# Altium schematic sheet 02_ESP32.SchDoc(02_ESP32)
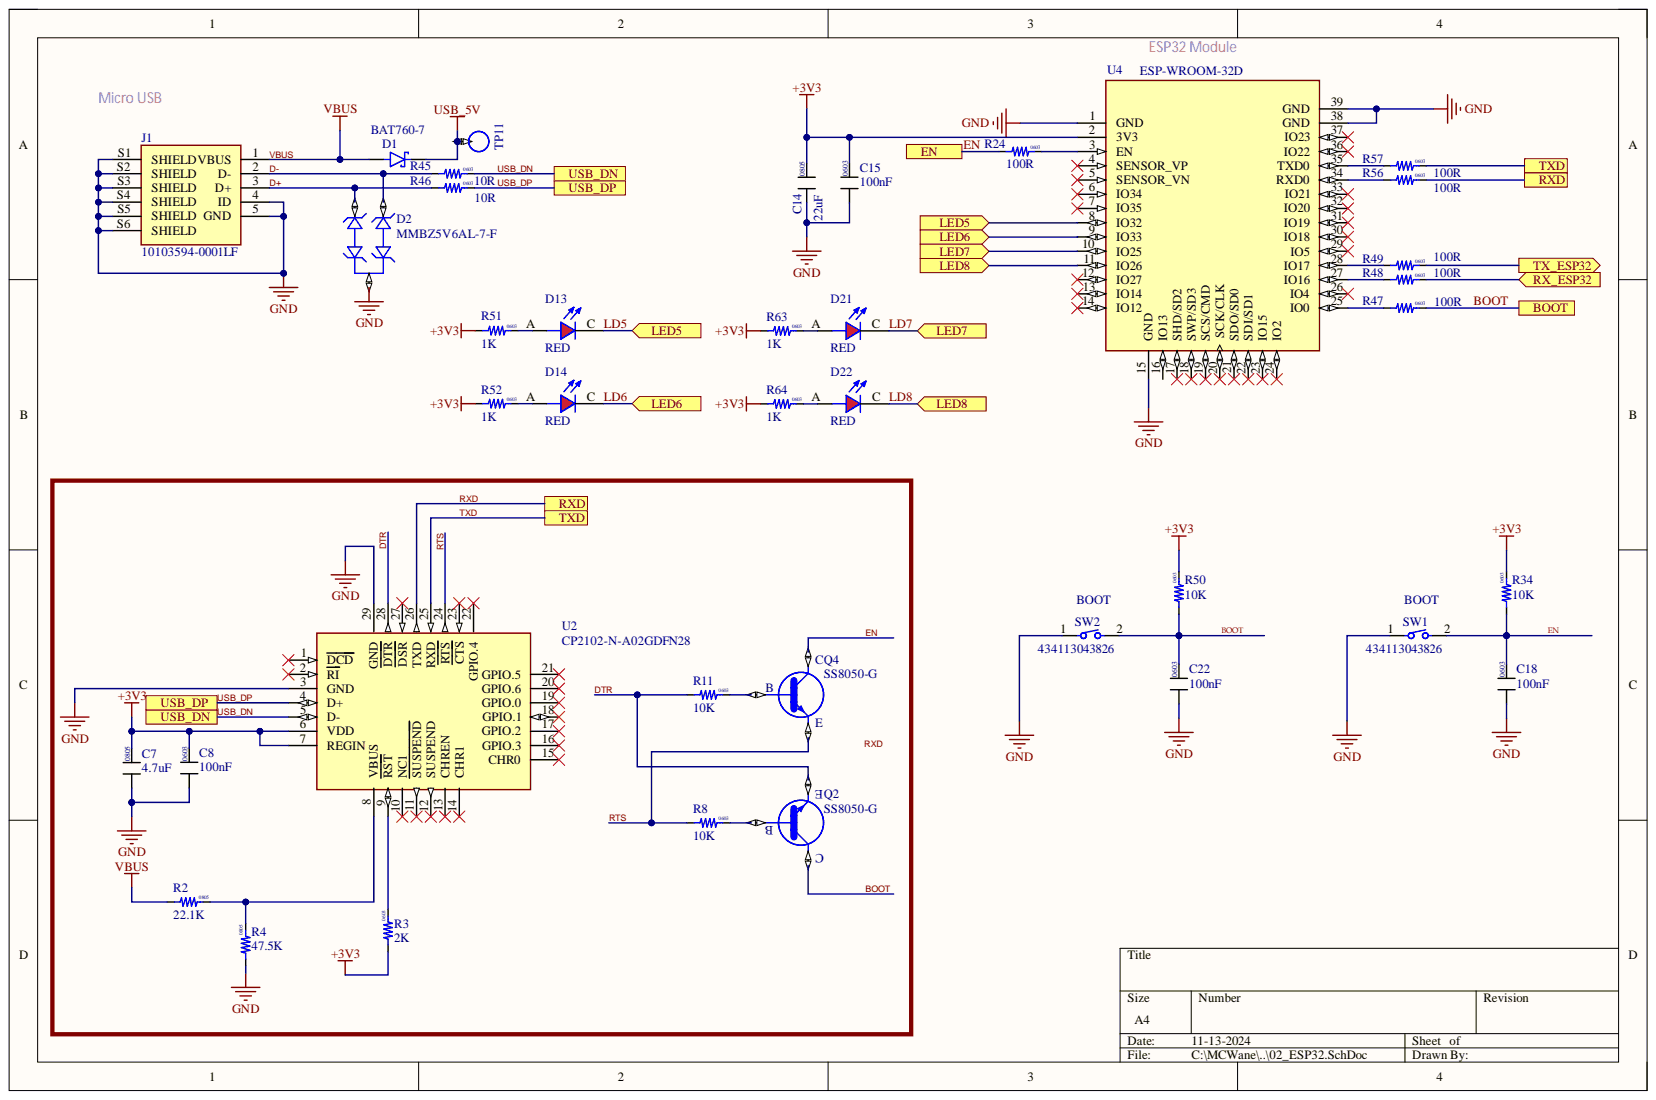
[diagram p0: 02_ESP32.SchDoc]

## Parts
C7  4.7uF  Package: 0805  [CAP_0805]
C8  100nF  Package: 0603  [CAP_0603]
C14  22uF  Package: 0805  [CAP_0805]
C15  100nF  Package: 0603  [CAP_0603]
C18  100nF  Package: 0603  [CAP_0603]
C22  100nF  Package: 0603  [CAP_0603]
D1  BAT760-7  [SOD323]
D2  MMBZ5V6AL-7-F  [SOT23]
D13  RED  [LED_0603]
D14  RED  [LED_0603]
D21  RED  [LED_0603]
D22  RED  [LED_0603]
J1  10103594-0001LF  [USB_MICRO_B_10118194-0001LF]
Q2  SS8050-G  [SOT23]
Q4  SS8050-G  [SOT23]
R2  22.1K  Package: 0805  [RES_0805]
R3  2K  Package: 0603  [RES_0603]
R4  47.5K  Package: 0805  [RES_0805]
R8  10K  Package: 0603  [RES_0603]
R11  10K  Package: 0603  [RES_0603]
R24  100R  Package: 0603  [RES_0603]
R34  10K  Package: 0603  [RES_0603]
R45  10R  Package: 0603  [RES_0603]
R46  10R  Package: 0603  [RES_0603]
R47  100R  Package: 0603  [RES_0603]
R48  100R  Package: 0603  [RES_0603]
R49  100R  Package: 0603  [RES_0603]
R50  10K  Package: 0603  [RES_0603]
R51  1K  Package: 0603  [RES_0603]
R52  1K  Package: 0603  [RES_0603]
R56  100R  Package: 0603  [RES_0603]
R57  100R  Package: 0603  [RES_0603]
R63  1K  Package: 0603  [RES_0603]
R64  1K  Package: 0603  [RES_0603]
SW1  434113043826  [Tactile_Switch_SPST_2Pin]
SW2  434113043826  [Tactile_Switch_SPST_2Pin]
TP11  TP  [TEST_POINT_SMD]
U2  CP2102-N-A02GDFN28  [QFN50P500X500X100-29N]
U4  ESP-WROOM-32D  [MODULE_ESP32-WROOM-32D]

## Ports
BOOT, EN, LED5, LED6, LED7, LED8, RX_ESP32, RXD, TX_ESP32, TXD, USB_DN, USB_DP

## Nets
+3V3: C7.2 C8.2 C14.2 C15.2 R3.1 R34.2 R50.2 R51.1 R52.1 R63.1 R64.1 U2.6(VDD) U2.7(REGIN) U4.2(3V3)
GND: C7.1 C8.1 C14.1 C15.1 C18.1 C22.1 D2.3 J1.4(ID) J1.5(GND) J1.S1(SHIELD) J1.S2(SHIELD) J1.S3(SHIELD) J1.S4(SHIELD) J1.S5(SHIELD) J1.S6(SHIELD) R4.1 SW1.1 SW2.1 U2.3(GND) U2.29(GND) U4.1(GND) U4.15(GND) U4.38(GND) U4.39(GND)
USB_5V: D1.C TP11.1
BOOT: C22.2 Q2.3 R47.2 R50.1 SW2.2 ; port BOOT
D+: D2.1 J1.3(D+) R46.1
D-: D2.2 J1.2(D-) R45.1
DTR: Q2.2 R11.1 U2.28(DTR)
EN: C18.2 Q4.3 R24.1 R34.1 SW1.2 ; port EN
LD5: D13.C U4.8(IO32) ; port LED5
LD6: D14.C U4.9(IO33) ; port LED6
LD7: D21.C U4.10(IO25) ; port LED7
LD8: D22.C U4.11(IO26) ; port LED8
RTS: Q4.2 R8.1 U2.24(RTS)
RX_ESP32: R48.2 ; port RX_ESP32
RXD: R56.2 U2.26(TXD) ; port RXD
TX_ESP32: R49.2 ; port TX_ESP32
TXD: R57.2 U2.25(RXD) ; port TXD
USB_DN: R45.2 U2.5(D-) ; port USB_DN
USB_DP: R46.2 U2.4(D+) ; port USB_DP
VBUS: D1.A J1.1(VBUS) R2.1
NetD13_A: D13.A R51.2
NetD14_A: D14.A R52.2
NetD21_A: D21.A R63.2
NetD22_A: D22.A R64.2
NetQ2_1: Q2.1 R8.2
NetQ4_1: Q4.1 R11.2
NetR2_2: R2.2 R4.2 U2.8(VBUS)
NetR3_2: R3.2 U2.9(RST)
NetR24_2: R24.2 U4.3(EN)
NetR47_1: R47.1 U4.25(IO0)
NetR48_1: R48.1 U4.27(IO16)
NetR49_1: R49.1 U4.28(IO17)
NetR56_1: R56.1 U4.34(RXD0)
NetR57_1: R57.1 U4.35(TXD0)

Not connected: U2 1(DCD) 2(RI) 10(NC1) 11(SUSPEND) 12(SUSPEND) 13(CHREN) 14(CHR1) 15(CHR0) 16(GPIO.3) 17(GPIO.2) 18(GPIO.1) 19(GPIO.0) 20(GPIO.6) 21(GPIO.5) 22(GPIO.4) 23(CTS) 27(DSR); U4 4(SENSOR_VP) 5(SENSOR_VN) 6(IO34) 7(IO35) 12(IO27) 13(IO14) 14(IO12) 16(IO13) 17(SHD/SD2) 18(SWP/SD3) 19(SCS/CMD) 20(SCK/CLK) 21(SDO/SD0) 22(SDI/SD1) 23(IO15) 24(IO2) 26(IO4) 29(IO5) 30(IO18) 31(IO19) 32(IO20) 33(IO21) 36(IO22) 37(IO23)

## Library data of the parts
C7 = C0805-4.7uF 25V-MLCC: SMD Multilayer Ceramic Capacitor, 4.7 µF, 25 V, 0805 [2012 Metric], ± 10%, X7R, -55 °C; Manufacturer Part Number: CC0805KKX7R8BB475; Brand: YAGEO; Marking: 475; Suppplier: ELEMENT 14; Component: Capacitor; Component Kind: Standard; Library Name: Passive_Components.SchLib
C8 C15 C18 C22 = C0603-0.1uF 50V-MLCC: SMD Multilayer Ceramic Capacitor, 0.1 µF, 50 V, 0603 [1608 Metric], ± 10%, X7R; Manufacturer Part Number: CC0603KRX7R9BB104; Brand: YAGEO; Marking: 104; Component: Capacitor; Component Kind: Standard; LCSC Part: C14663; Library Name: Passive_Components.SchLib; Supplier: ELEMENT 14
C14 = C0805-22uF 25V-MLCC: SMD Multilayer Ceramic Capacitor, 22 µF, 25 V, 0805 [2012 Metric], ± 20%, X5R, CC; Manufacturer Part Number: CC0805MKX5R8BB226; Brand: YAGEO; Marking: 226; Component: Capacitor; Component Kind: Standard; LCSC Part: C784585; Library Name: Passive_Components.SchLib; Supplier: ELEMENT 14
D1 = BAT760-7: Schottky Rectifier, 30 V, 1 A, Single, SOD-323, 2 Pins, 550 mV; Brand: DIODES INC.; Marking: LZ; Component Kind: Standard; Library Name: Active_Components.SchLib; Manufacturer Part Number: BAT760-7; Package: SOD-323
D2 = MMBZ5V6AL-7-F: ESD Suppressors / TVS Diodes 5.6V 225mW; Brand: Diodes Incorporated; Component Kind: Standard; Library Name: Active_Components.SchLib; Manufacturer Part Number: MMBZ5V6AL-7-F; Package: SOT-23-3
D13 D14 D21 D22 = RED_LED_0603: 5mA 28.5mcd 2.2V 632nm Colorless transparence -40℃~+85℃ 617.5nm~633.5nm Red 120° 60mW 0603(LED); Manufacturer Part Number: 19-217/R6C-AL1M2VY/3T; Brand: Everlight Elec; Component Kind: Standard; Library Name: Active_Components.SchLib; Package: 0603
J1 = 10103594-0001LF: USB Connector, Micro USB Type B, USB 2.0, Receptacle, 5 Ways, Surface Mount, Right Angle; Brand: Amphenol ICC (FCI); Component Kind: Standard; Library Name: CONNECTOR.SchLib; Manufacturer Part Number: 10103594-0001LF; Package: Surface Mount, Right Angle; Through Hole
Q2 Q4 = SS8050-G: Bipolar Transistors - BJT NPN TRANSISTOR 1.5A 40V; Brand: Comchip Technology; Component Kind: Standard; Library Name: Active_Components.SchLib; Manufacturer Part Number: SS8050-G; Package: SOT23-3
R2 = R0805-22.1K-1%: SMD Chip Resistor, 22.1 kohm, ± 1%, 125 mW, 0805 [2012 Metric], Thick Film, General Purpose; Manufacturer Part Number: RC0805FR-0722K1L; Brand: YAGEO; Marking: 2212; Component: Resistor; Component Kind: Standard; Library Name: Passive_Components.SchLib; Supplier: ELEMENT 14
R3 = R0603-2K-1%: SMD Chip Resistor, 2 kohm, ± 1%, 100 mW, 0603 [1608 Metric], Thick Film, General Purpose; Manufacturer Part Number: RC0603FR-072KL; Brand: YAGEO; Marking: 2001; Component: Resistor; Component Kind: Standard; LCSC Part: C105576; Library Name: Passive_Components.SchLib; Supplier: ELEMENT 14
R4 = R0805-47.5K-1%: SMD Chip Resistor, 47.5 kohm, ± 1%, 125 mW, 0805 [2012 Metric], Thick Film, General Purpose; Manufacturer Part Number: RC0805FR-0747K5L; Brand: YAGEO; Marking: 4752; Component: Resistor; Component Kind: Standard; Library Name: Passive_Components.SchLib; Supplier: ELEMENT 14
R8 R11 R34 R50 = R0603-10K-1%: SMD Chip Resistor, 10 kohm, ± 1%, 100 mW, 0603 [1608 Metric], Thick Film, General Purpose; Manufacturer Part Number: RC0603FR-0710KL; Brand: YAGEO; Marking: 1002; Component: Resistor; Component Kind: Standard; LCSC Part: C98220; Library Name: Passive_Components.SchLib; Supplier: ELEMENT 14
R24 R47-R49 R56 R57 = R0603-100E-1%: SMD Chip Resistor, 100 ohm, ± 1%, 100 mW, 0603 [1608 Metric], Thick Film, General Purpose; Manufacturer Part Number: RC0603FR-07100RL; Brand: YAGEO; Component: Resistor; Component Kind: Standard; Library Name: Passive_Components.SchLib; Marking: 100R; Supplier: ELEMENT 14
R45 R46 = R0603-10E-1%: SMD Chip Resistor, 10 ohm, ± 1%, 100 mW, 0603 [1608 Metric], Thick Film, General Purpose; Manufacturer Part Number: RC0603FR-0710RL; Brand: YAGEO; Marking: 10RO; Component: Resistor; Component Kind: Standard; LCSC Part: C109318; Library Name: Passive_Components.SchLib; Supplier: ELEMENT 14
R51 R52 R63 R64 = R0603-1K-1%: SMD Chip Resistor, 1 kohm, ± 1%, 100 mW, 0603 [1608 Metric], Thick Film, General Purpose; Manufacturer Part Number: RC0603FR-071KL; Brand: YAGEO; Marking: 1001; Component: Resistor; Component Kind: Standard; LCSC Part: C109318; Library Name: Passive_Components.SchLib; Supplier: ELEMENT 14
SW1 SW2 = 434113043826: Tactile Switches WS-TASV Tact Switch; Brand: Wurth Elektronik; Component Kind: Standard; Library Name: CONNECTOR.SchLib; Manufacturer Part Number: 434113043826; Package: 6mmx3.5mm, SMD
TP11 = TEST_POINT_SMD: Component Kind: Standard; Library Name: CONNECTOR.SchLib
U2 = CP2102-GM: USB Bridge, USB to UART USB 2.0 UART Interface 28-QFN (5x5); Brand: Silicon Labs; Component Kind: Standard; Library Name: Active_Components.SchLib; Manufacturer Part Number: CP2102-GM; Package: 28-VFQFN Exposed Pad.
U4 = ESP32-WROOM-32D: Bluetooth, WiFi 802.11b/g/n, Bluetooth v4.2 + EDR, Class 1, 2 and 3 Transceiver Module 2.4GHz ~ 2.5GHz Integrated, Trace Surface Mount; Brand: Espressif Systems; Component Kind: Standard; LCSC Part: C529577; Library Name: Active_Components.SchLib; Package: ESP32-WROOM-32D
